# Altium schematic sheet ADC_Input.SchDoc
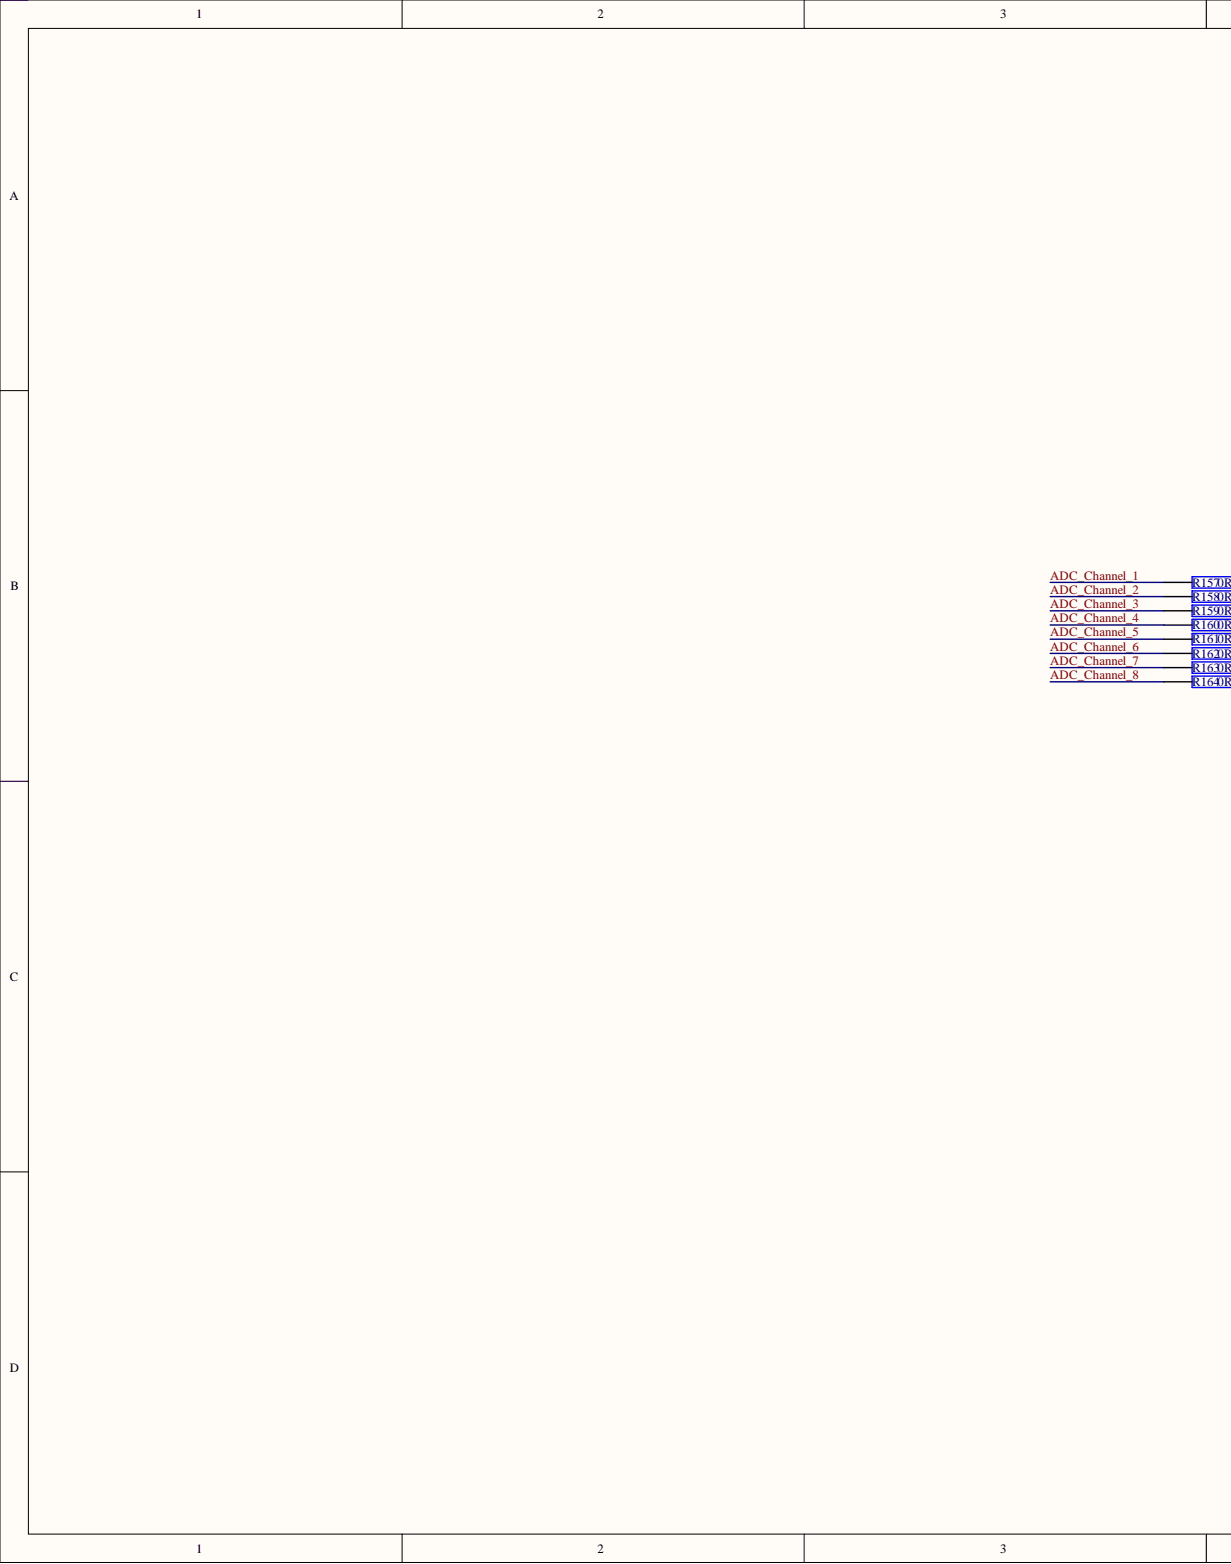
[diagram p0: ADC_Input.SchDoc, left]
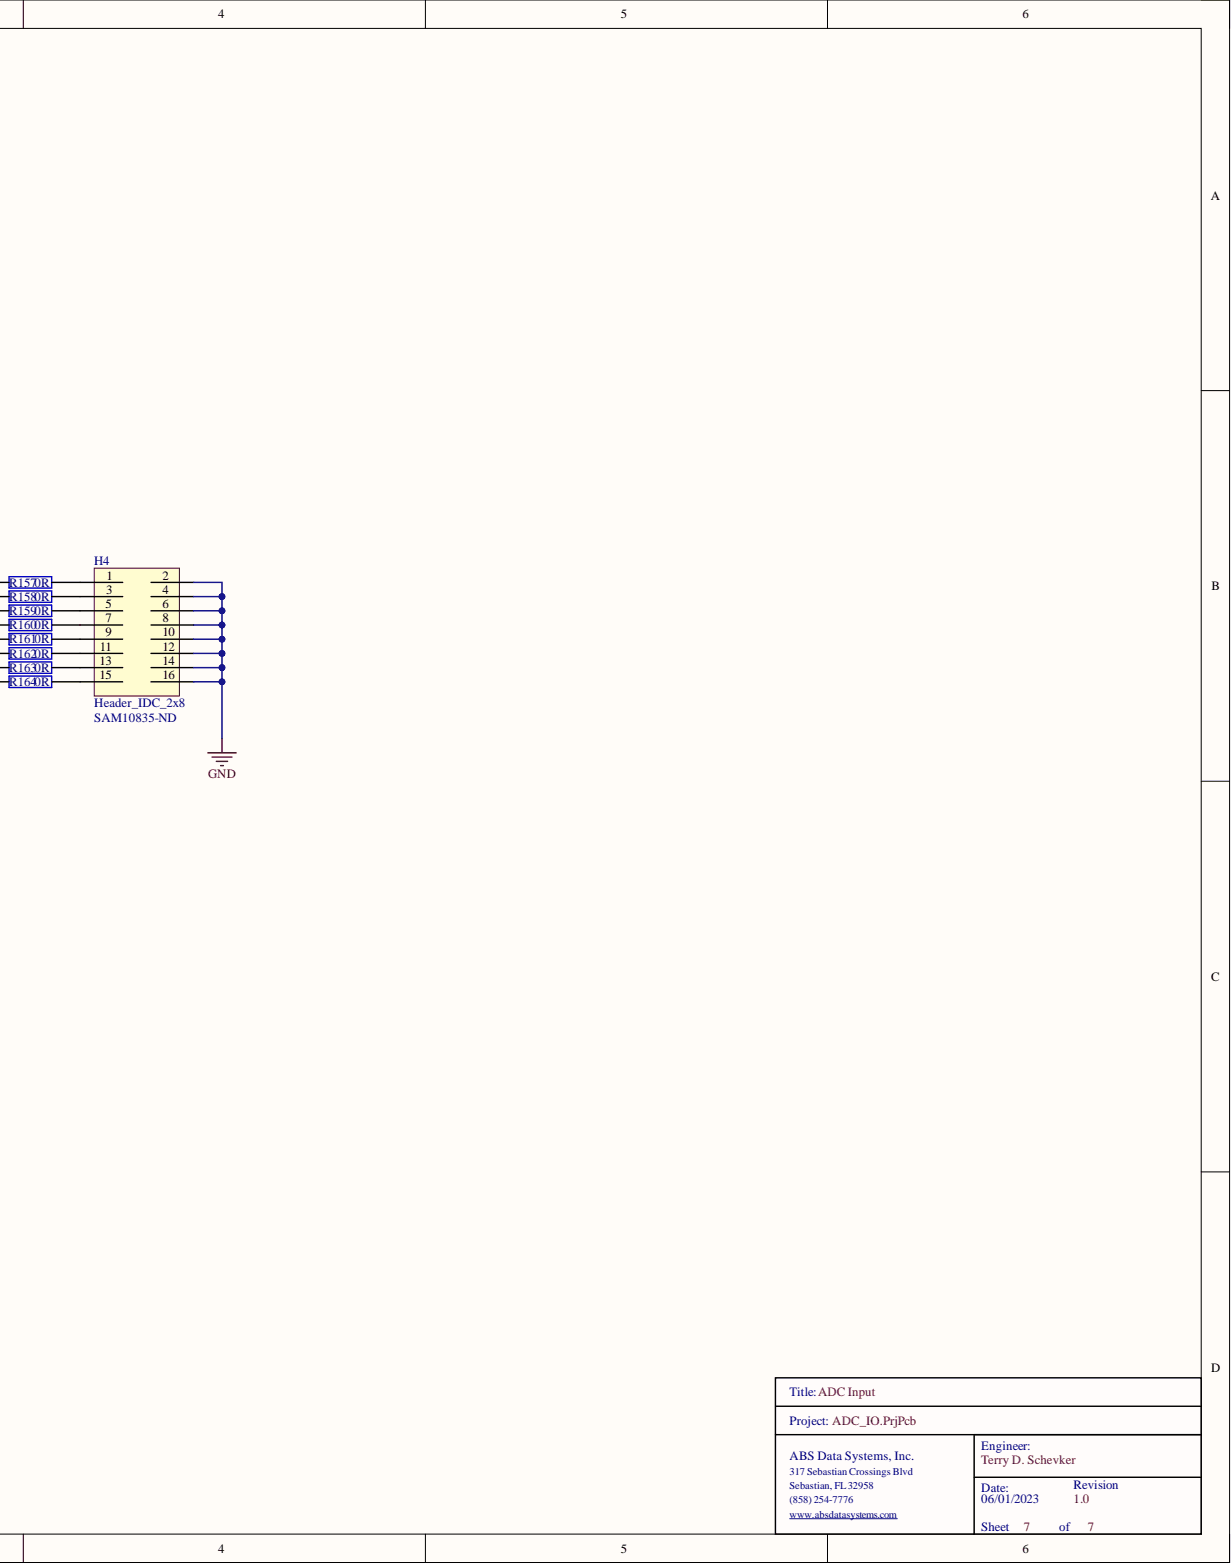
[diagram p1: ADC_Input.SchDoc, right]

## Parts
H4  Header_IDC_2x8  Supplier Part Number 1: SAM10835-ND  [HEADER16]
R157  0R  [0603]  (value not drawn)
R158  0R  [0603]  (value not drawn)
R159  0R  [0603]  (value not drawn)
R160  0R  [0603]  (value not drawn)
R161  0R  [0603]  (value not drawn)
R162  0R  [0603]  (value not drawn)
R163  0R  [0603]  (value not drawn)
R164  0R  [0603]  (value not drawn)

## Nets
GND: H4.2 H4.4 H4.6 H4.8 H4.10 H4.12 H4.14 H4.16
ADC_Channel_1: R157.1
ADC_Channel_2: R158.1
ADC_Channel_3: R159.1
ADC_Channel_4: R160.1
ADC_Channel_5: R161.1
ADC_Channel_6: R162.1
ADC_Channel_7: R163.1
ADC_Channel_8: R164.1
NetH4_1: H4.1 R157.2
NetH4_3: H4.3 R158.2
NetH4_5: H4.5 R159.2
NetH4_7: H4.7 R160.2
NetH4_9: H4.9 R161.2
NetH4_11: H4.11 R162.2
NetH4_13: H4.13 R163.2
NetH4_15: H4.15 R164.2

## Library data of the parts
H4 = Header_IDC_2x8: 16 Pin 2x8 Through Hold IDC Connector; Manufacturer: Samtec; Manufacturer Part Number: TST-108-01-G-D; Connector Type: Male Pin; Gender: Male; Number of Contacts: 16; Pitch: 2.54mm; Row Spacing: 0.1inch; Category: Headers and Wire Housings; Color: Black; Component Kind: Standard; ComponentLink1Description: https://datasheet.ciiva.com/739/tst-mkt-739845.pdf?src-supplier=Digi-Key; ComponentLink1URL: https://datasheet.ciiva.com/739/tst-mkt-739845.pdf?src-supplier=Digi-Key; ComponentLink2Description: https://datasheet.ciiva.com/10018/htst-10018510.pdf?src-supplier=Avnet; ComponentLink2URL: https://datasheet.ciiva.com/10018/htst-10018510.pdf?src-supplier=Avnet; Contact Material: Bronze; Contact Mating Length: 0.25inch; Contact Plating: Gold; Depth: 9.27mm; Housing Color: Black; Housing Material: Polyester; Length: 27.94mm; Library Name: Misc.SchLib; Max Operating Temperature: 125°C; Min Operating Temperature: -55°C; Mount: Through Hole; Number of Positions: 16; Number of Rows: 2; Orientation: Straight; Packaging: Rail/Tube; Pricing 1: 1=2.85000, 10=2.73600, 100=2.28000, 500=1.93800, 1000=1.65300, 5000=1.48200 (USD); REACH SVHC: No SVHC; RoHS: Yes; Stock 1: 227; Supplier 1: Digi-Key; Termination: Solder
R157-R164 = RC0603JR-070RL: YAGEO 0603 0 ohm; Manufacturer_Name: KEMET; Geometry.Height: 0.55mm; Component Kind: Standard; Datasheet Link: https://datasheet.datasheetarchive.com/originals/distributors/Datasheets_SAMA/902f9e387b938f871d31120f5fc1d65e.pdf; Library Name: Resistors.SchLib; Manufacturer_Part_Number: RC0603JR-070RL; Mouser Part Number: 603-RC0603JR-070RL; Mouser Price/Stock: https://www.mouser.co.uk/ProductDetail/YAGEO/RC0603JR-070RL?qs=2cAdsCoAWRGWyPcuXgGiXQ%3D%3D
